# Altium schematic sheet H-CUBE.SchDoc
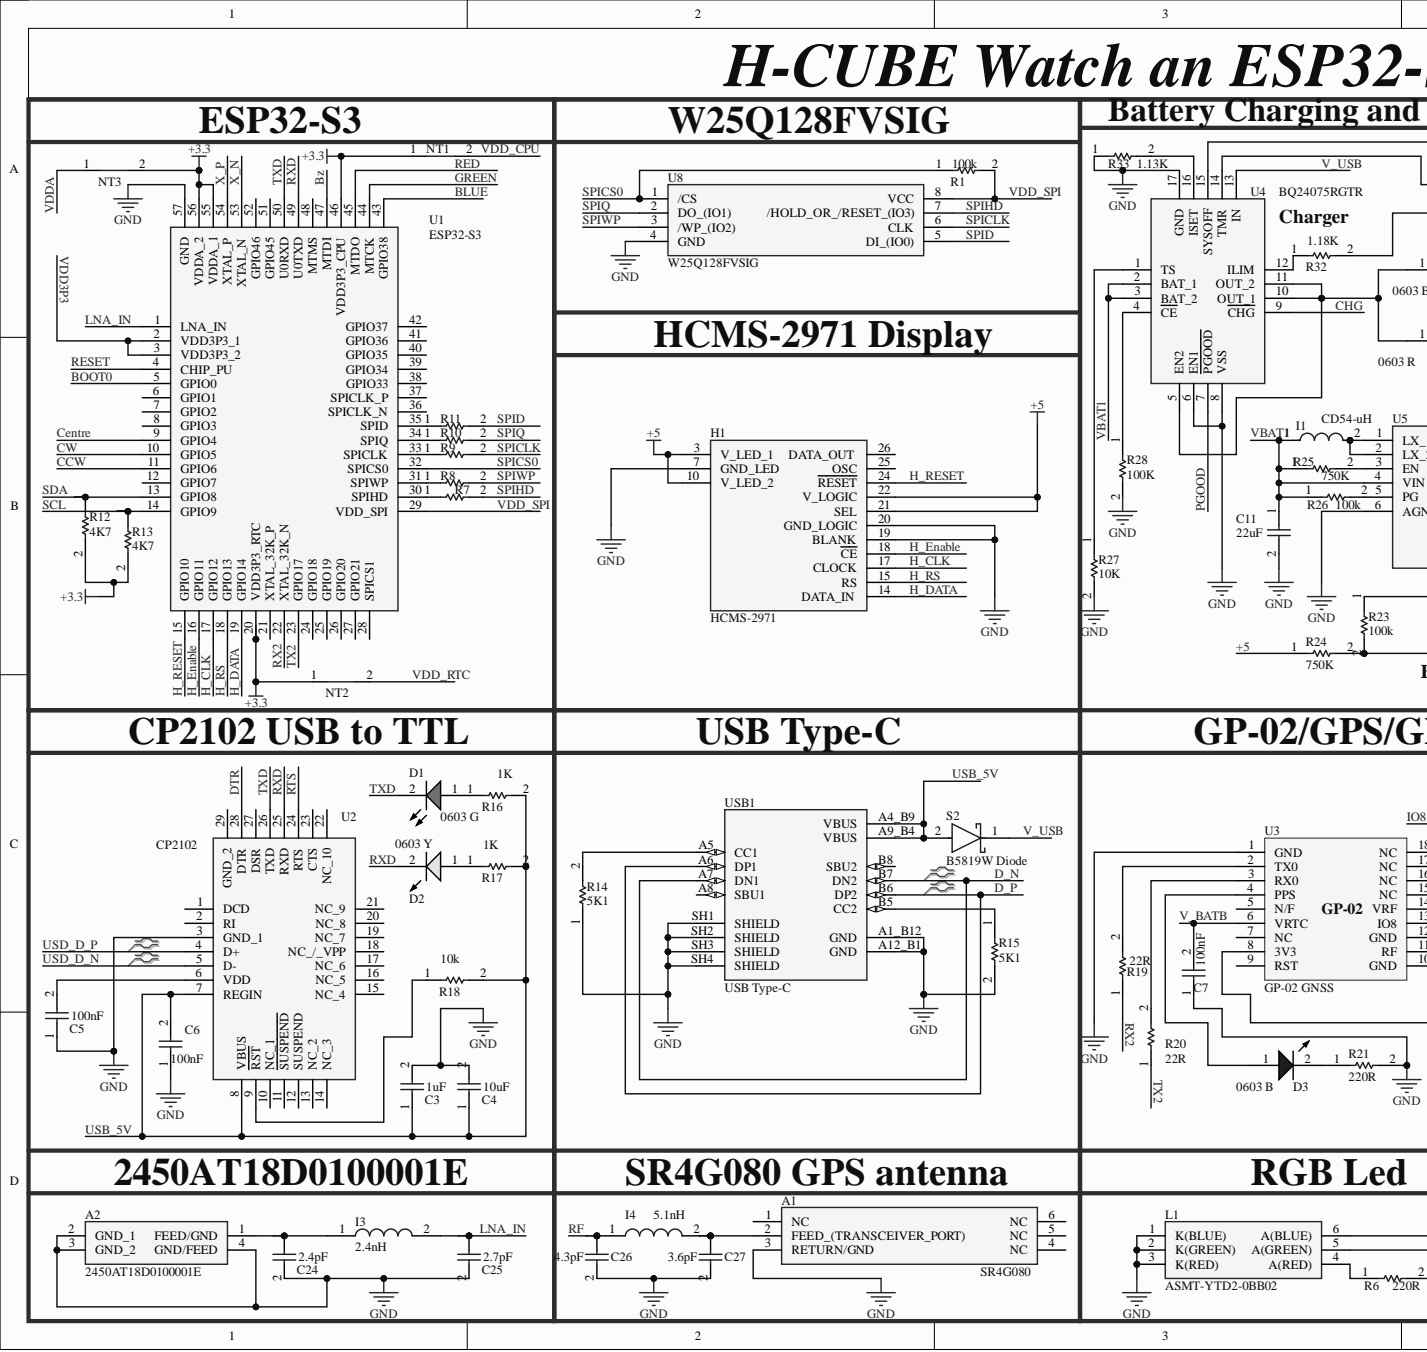
[diagram p0: H-CUBE.SchDoc, left]
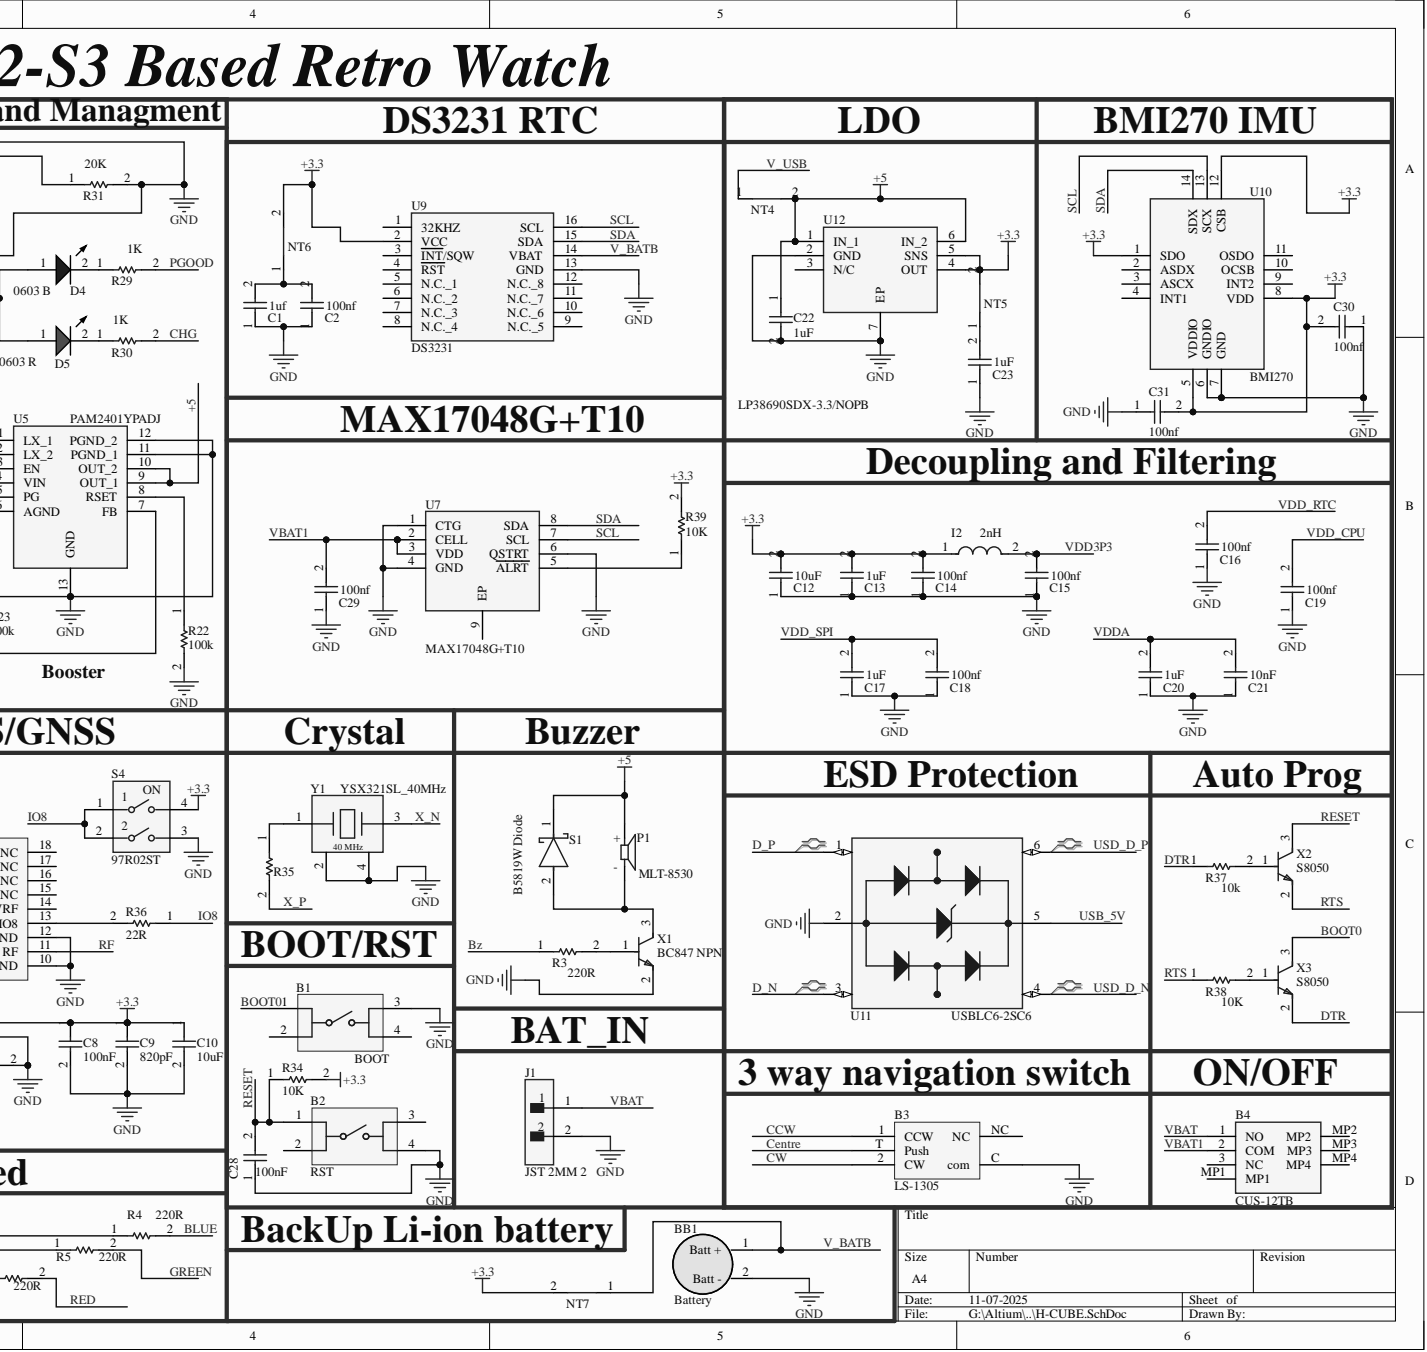
[diagram p1: H-CUBE.SchDoc, right]

## Parts
A1  SR4G080  [SR4G080]
A2  2450AT18D0100001E  [2450AT18D0100001E]
B1  BOOT  [YD-3414]
B2  RST  [YD-3414]
B3  LS-1305  [LS-1305]
B4  CUS-12TB  [CUS-12TB]
BB1  Battery  [Battery]
C1  1uf  [0603 CER CAP]
C2  100nf  [0603 CER CAP]
C3  1uF  [0603 CER CAP]
C4  10uF  [0603 CER CAP]
C5  100nF  [0603 CER CAP]
C6  100nF  [0603 CER CAP]
C7  100nF  [0603 CER CAP]
C8  100nF  [0603 CER CAP]
C9  820pF  [0603 CER CAP]
C10  10uF  [0603 CER CAP]
C11  22uF  [0603 CER CAP]
C12  10uF  [0603 CER CAP]
C13  1uF  [0603 CER CAP]
C14  100nf  [0603 CER CAP]
C15  100nf  [0603 CER CAP]
C16  100nf  [0603 CER CAP]
C17  1uF  [0603 CER CAP]
C18  100nf  [0603 CER CAP]
C19  100nf  [0603 CER CAP]
C20  1uF  [0603 CER CAP]
C21  10nF  [0603 CER CAP]
C22  1uF  [0603 CER CAP]
C23  1uF  [0603 CER CAP]
C24  2.4pF  [CER_0402_CAP]
C25  2.7pF  [CER_0402_CAP]
C26  4.3pF  [CER_0402_CAP]
C27  3.6pF  [CER_0402_CAP]
C28  100nF  [0603 CER CAP]
C29  100nf  [0603 CER CAP]
C30  100nf  [0603 CER CAP]
C31  100nf  [0603 CER CAP]
D1  0603 G  [0603 LED G]
D2  0603 Y  [0603 Y]
D3  0603 B  [0603 B]
D4  0603 B  [0603 B]
D5  0603 R  [0603 R]
H1  HCMS-2971  [HCMS-2971]
I1  CD54-uH  [CD54 Power inductor]
I2  2nH  [744761020A]
I3  2.4nH  [LQG15WZ2N4B02D]
I4  5.1nH  [LQG15HN5N1S02D]
J1  JST 2MM 2  [JST 2MM 2]
L1  ASMT-YTD2-0BB02  [ASMT-YTD2-0BB02]
NT1  NT  [NT']
NT2  NT  [NT']
NT3  NT  [NT']
NT4  NT  [NT']
NT5  NT  [NT']
NT6  NT  [NT']
NT7  NT  [NT']
P1  MLT-8530  [MLT-8530]
R1  100k  [0603 SMD RES]
R3  220R  [0603 SMD RES]
R4  220R  [0603 SMD RES]
R5  220R  [0603 SMD RES]
R6  220R  [0603 SMD RES]
R7  0R  [0603 SMD RES]  (value not drawn)
R8  0R  [0603 SMD RES]  (value not drawn)
R9  0R  [0603 SMD RES]  (value not drawn)
R10  0R  [0603 SMD RES]  (value not drawn)
R11  0R  [0603 SMD RES]  (value not drawn)
R12  4K7  [0603 SMD RES]
R13  4K7  [0603 SMD RES]
R14  5K1  [0603 SMD RES]
R15  5K1  [0603 SMD RES]
R16  1K  [0603 SMD RES]
R17  1K  [0603 SMD RES]
R18  10k  [0603 SMD RES]
R19  22R  [0603 SMD RES]
R20  22R  [0603 SMD RES]
R21  220R  [0603 SMD RES]
R22  100k  [0603 SMD RES]
R23  100k  [0603 SMD RES]
R24  750K  [0603 SMD RES]
R25  750K  [0603 SMD RES]
R26  100k  [0603 SMD RES]
R27  10K  [0603 SMD RES]
R28  100K  [0603 SMD RES]
R29  1K  [0603 SMD RES]
R30  1K  [0603 SMD RES]
R31  20K  [0603 SMD RES]
R32  1.18K  [0603 SMD RES]
R33  1.13K  [0603 SMD RES]
R34  10K  [0603 SMD RES]
R35  0R  [0603 SMD RES]  (value not drawn)
R36  22R  [0603 SMD RES]
R37  10k  [0603 SMD RES]
R38  10K  [0603 SMD RES]
R39  10K  [0603 SMD RES]
S1  B5819W Diode  [B5819W]
S2  B5819W Diode  [B5819W]
S4  97R02ST  [97R02ST]
U1  ESP32-S3  [ESP32-S3]
U2  CP2102  [CP2102]
U3  GP-02 GNSS  [GP-02]
U4  BQ24075RGTR  [BQ24075RGTR]
U5  PAM2401YPADJ  [PAM2401YPADJ]
U7  MAX17048G+T10  [MAX17048G+T10]
U8  W25Q128FVSIG  [W25Q128FVSIG]
U9  DS3231  [DS3231]
U10  BMI270  [BMI270]
U11  USBLC6-2SC6  [USBLC6-2SC6]
U12  LP38690SDX-3.3/NOPB  [LP38690SDX-3.3/NOPB]
USB1  USB Type-C  [USB Type-C 16P]
X1  BC847 NPN  [BC847]
X2  S8050  [S8050 J3Y]
X3  S8050  [S8050 J3Y]
Y1  YSX321SL_40MHz  [YSX321SL]

## Nets
+3.3: C8.1 C9.1 C10.1 C12.2 C13.2 C14.2 C30.2 C31.2 I2.1 NT1.1 NT2.1 NT3.2 NT5.2 NT6.2 NT7.2 R12.2 R13.2 R34.2 R39.2 S4.4 U1.20(VDD3P3_RTC) U1.46(VDD3P3_CPU) U1.55(VDDA_1) U1.56(VDDA_2) U3.8(3V3) U9.2(VCC) U10.1(SDO) U10.5(VDDIO) U10.8(VDD) U10.12(CSB) U12.4(OUT) U12.5(SNS)
GND: A1.3(RETURN/GND) A2.2(GND_1) A2.3(GND_2) A2.4(GND/FEED) B1.3 B2.4 B3.C(com) BB1.2 C1.1 C2.1 C3.2 C4.2 C5.1 C6.1 C7.1 C8.2 C9.2 C10.2 C11.2 C12.1 C13.1 C14.1 C15.1 C16.1 C17.1 C18.1 C19.1 C20.1 C21.1 C22.2 C23.1 C24.2 C25.2 C26.2 C27.2 C28.1 C29.1 C30.1 C31.1 H1.7(GND_LED) H1.19(BLANK) H1.20(GND_LOGIC) J1.2 L1.1(K(BLUE)) L1.2(K(GREEN)) L1.3(K(RED)) R14.1 R15.2 R21.2 R22.2 R23.1 R27.2 R28.2 R31.2 R32.2 R33.1 S4.3 U1.57(GND) U2.3(GND_1) U3.1(GND) U3.10(GND) U3.12(GND) U4.6(EN1) U4.8(VSS) U4.15(SYSOFF) U4.17(GND) U5.6(AGND) U5.11(PGND_1) U5.12(PGND_2) U5.13(GND) U7.1(CTG) U7.4(GND) U7.6(QSTRT) U8.4(GND) U9.13(GND) U10.6(GNDIO) U10.7(GND) U11.2 U12.2(GND) U12.7(EP) USB1.A1_B12(GND) USB1.A12_B1(GND) USB1.SH1(SHIELD) USB1.SH2(SHIELD) USB1.SH3(SHIELD) USB1.SH4(SHIELD) X1.2 Y1.2 Y1.4
+5: C22.1 H1.3(V_LED_1) H1.10(V_LED_2) H1.21(SEL) H1.22(V_LOGIC) NT4.2 P1.P R24.1 S1.1 U5.9(OUT_1) U5.10(OUT_2) U12.1(IN_1) U12.6(IN_2)
BLUE: R4.2 U1.43(GPIO38)
BOOT0: B1.1 U1.5(GPIO0) X3.3
Bz: R3.1 U1.47(MTDI)
CCW: B3.1(CCW) U1.11(GPIO6)
Centre: B3.T(Push) U1.9(GPIO4)
CHG: R30.2 U4.9(CHG)
CW: B3.2(CW) U1.10(GPIO5)
D_N: U11.3 USB1.A7(DN1) USB1.B7(DN2)
D_P: U11.1 USB1.A6(DP1) USB1.B6(DP2)
DTR: R37.1 U2.28(DTR) X3.2
GREEN: R5.2 U1.44(MTCK)
H_CLK: H1.17(CLOCK) U1.17(GPIO12)
H_DATA: H1.14(DATA_IN) U1.19(GPIO14)
H_Enable: H1.18(CE) U1.16(GPIO11)
H_RESET: H1.24(RESET) U1.15(GPIO10)
H_RS: H1.15(RS) U1.18(GPIO13)
IO8: R36.1 S4.1 S4.2
LNA_IN: C25.1 I3.2 U1.1(LNA_IN)
PGOOD: R29.2 U4.7(PGOOD)
RED: R6.2 U1.45(MTDO)
RESET: B2.1 C28.2 R34.1 U1.4(CHIP_PU) X2.3
RF: C26.1 I4.1 U3.11(RF)
RTS: R38.1 U2.24(RTS) X2.2
RX2: R19.1 U1.22(XTAL_32K_N)
RXD: D2.2 U1.49(U0TXD) U2.25(RXD)
SCL: R13.1 U1.14(GPIO9) U7.7(SCL) U9.16(SCL) U10.13(SCX)
SDA: R12.1 U1.13(GPIO8) U7.8(SDA) U9.15(SDA) U10.14(SDX)
SPICLK: R9.2 U8.6(CLK)
SPICS0: R1.1 U1.32(SPICS0) U8.1(/CS)
SPID: R11.2 U8.5(DI_(IO0))
SPIHD: R7.2 U8.7(/HOLD_OR_/RESET_(IO3))
SPIQ: R10.2 U8.2(DO_(IO1))
SPIWP: R8.2 U8.3(/WP_(IO2))
TX2: R20.1 U1.23(GPIO17)
TXD: D1.2 U1.50(U0RXD) U2.26(TXD)
USB_5V: C3.1 C4.1 C6.2 R16.2 R17.2 R18.2 S2.2 U2.7(REGIN) U2.8(VBUS) U11.5 USB1.A4_B9(VBUS) USB1.A9_B4(VBUS)
USD_D_N: U2.5(D-) U11.4
USD_D_P: U2.4(D+) U11.6
V_BATB: BB1.1 C7.2 NT7.1 U3.6(VRTC) U9.14(VBAT)
V_USB: NT4.1 S2.1 U4.13(IN)
VBAT: B4.1(NO) J1.1
VBAT1: B4.2(COM) C11.1 C29.2 I1.1 R25.1 R26.1 U4.2(BAT_1) U4.3(BAT_2) U5.4(VIN) U7.2(CELL) U7.3(VDD)
VDD3P3: C15.2 I2.2 U1.2(VDD3P3_1) U1.3(VDD3P3_2)
VDD_CPU: C19.2 NT1.2
VDD_RTC: C16.2 NT2.2
VDD_SPI: C17.2 C18.2 R1.2 U1.29(VDD_SPI) U8.8(VCC)
VDDA: C20.2 C21.2 NT3.1
X_N: U1.53(XTAL_N) Y1.3
X_P: R35.2 U1.54(XTAL_P)
NetA1_2: A1.2(FEED_(TRANSCEIVER_PORT)) C27.1 I4.2
NetA2_1: A2.1(FEED/GND) C24.1 I3.1
NetC1_2: C1.2 C2.2 NT6.1
NetC5_2: C5.2 U2.6(VDD)
NetC23_2: C23.2 NT5.1
NetD1_1: D1.1 R16.1
NetD2_1: D2.1 R17.1
NetD3_1: D3.1 U3.4(PPS)
NetD3_2: D3.2 R21.1
NetD4_1: D4.1 D5.1 U4.5(EN2) U4.10(OUT_1) U4.11(OUT_2)
NetD4_2: D4.2 R29.1
NetD5_2: D5.2 R30.1
NetI1_2: I1.2 U5.1(LX_1) U5.2(LX_2)
NetL1_4: L1.4(A(RED)) R6.1
NetL1_5: L1.5(A(GREEN)) R5.1
NetL1_6: L1.6(A(BLUE)) R4.1
NetP1_N: P1.N S1.2 X1.3
NetR3_2: R3.2 X1.1
NetR7_1: R7.1 U1.30(SPIHD)
NetR8_1: R8.1 U1.31(SPIWP)
NetR9_1: R9.1 U1.33(SPICLK)
NetR10_1: R10.1 U1.34(SPIQ)
NetR11_1: R11.1 U1.35(SPID)
NetR14_2: R14.2 USB1.A5(CC1)
NetR15_1: R15.1 USB1.B5(CC2)
NetR18_1: R18.1 U2.9(RST)
NetR19_2: R19.2 U3.2(TX0)
NetR20_2: R20.2 U3.3(RX0)
NetR22_1: R22.1 U5.8(RSET)
NetR23_2: R23.2 R24.2 U5.7(FB)
NetR25_2: R25.2 U5.3(EN)
NetR26_2: R26.2 U5.5(PG)
NetR27_1: R27.1 U4.1(TS)
NetR28_1: R28.1 U4.4(CE)
NetR31_1: R31.1 U4.14(TMR)
NetR32_1: R32.1 U4.12(ILIM)
NetR33_2: R33.2 U4.16(ISET)
NetR35_1: R35.1 Y1.1
NetR36_2: R36.2 U3.13(IO8)
NetR37_2: R37.2 X2.1
NetR38_2: R38.2 X3.1
NetR39_1: R39.1 U7.5(ALRT)

Not connected: A1 1(NC) 4(NC) 5(NC) 6(NC); B1 2 4; B2 2 3; B3 NC; B4 3(NC) MP1 MP2 MP3 MP4; H1 25(OSC) 26(DATA_OUT); U1 6(GPIO1) 7(GPIO2) 8(GPIO3) 12(GPIO7) 21(XTAL_32K_P) 24(GPIO18) 25(GPIO19) 26(GPIO20) 27(GPIO21) 28(SPICS1) 36(SPICLK_N) 37(SPICLK_P) 38(GPIO33) 39(GPIO34) 40(GPIO35) 41(GPIO36) 42(GPIO37) 48(MTMS) 51(GPIO45) 52(GPIO46); U2 1(DCD) 2(RI) 10(NC_1) 11(SUSPEND) 12(SUSPEND) 13(NC_2) 14(NC_3) 15(NC_4) 16(NC_5) 17(NC_6) 18(NC_/_VPP) 19(NC_7) 20(NC_8) 21(NC_9) 22(NC_10) 23(CTS) 27(DSR) 29(GND_2); U3 5(N/F) 7(NC) 9(RST) 14(VRF) 15(NC) 16(NC) 17(NC) 18(NC); U7 9(EP); U9 1(32KHZ) 3(INT/SQW) 4(RST) 5(N.C._1) 6(N.C._2) 7(N.C._3) 8(N.C._4) 9(N.C._5) 10(N.C._6) 11(N.C._7) 12(N.C._8); U10 2(ASDX) 3(ASCX) 4(INT1) 9(INT2) 10(OCSB) 11(OSDO); U12 3(N/C); USB1 A8(SBU1) B8(SBU2)

## Library data of the parts
A1 = SR4G080: GNSS Antenna chip type; Component Kind: Standard; Library Name: Antenna.SchLib
A2 = 2450AT18D0100001E: 2.4GHz Chip RF Antenna 2.4GHz ~ 2.5GHz 1.5dBi Solder Surface Mount; Component Kind: Standard; Library Name: Antenna.SchLib
B1 B2 = YD-3414: Component Kind: Standard; Library Name: Switches.SchLib
B3 = LS-1305: 3 way navigation switch  Spring return, center push, Single knob can move CW/CCW/PUSH                                                          Specification:-  Rating    10mA.5V DC  Contact resitance    100MΩ max.  Operating temperature range    -30°C to +85°C  Lifetime    100,000 Cycles min  Operating force    Lever portion 120±30gf   /  Push portion205±50gf  Travel    3-direction-25°-0°~+25° / push portion 0.90±0.1mm; Component Kind: Standard; Library Name: Switches.SchLib
C1-C23 C28-C31 = 0603_SMD: 0603 ceramic capacitor; Component Kind: Standard; Library Name: Non_Polar Capacitor.SchLib
C24-C27 = 0402_SMD: 0402; Component Kind: Standard; Library Name: Non_Polar Capacitor.SchLib
H1 = HCMS-2971: LED Displays & Accessories Yelow 585nm 1x8 Alphanumeric; Component Kind: Standard; Library Name: Displays.SchLib
I1 = CD54 Inductor: Fixed Inductors SMD Power Inductor Unshielded; Component Kind: Standard; Library Name: SMD Components.SchLib
I2 = 744761020A: RF Inductors - SMD WE-KI 0603 2nH 700mA 80mOhms 0.2nH AEC-Q200; Component Kind: Standard; Library Name: SMD Components.SchLib
I3 = LQG15WZ2N4B02D: Component Kind: Standard; Library Name: SMD Components.SchLib
I4 = LQG15HN5N1S02D: Component Kind: Standard; Library Name: SMD Components.SchLib
P1 = MLT-8530: PCB Mount type, SMD, External Drive, Magnetic 2700Hz 80dB Size Square 8.5x8.5x3mm, Low Profile, Sound output on side.; Component Kind: Standard; Library Name: Misc Components.SchLib
R1 R3-R39 = RES_0603_SMD: Component Kind: Standard; Library Name: Resistors.SchLib
S1 S2 = B5819W: 1N5819 B5819W 1N5819W SOD123 (1206) 1A 40V Schottky Diode; Component Kind: Standard; Library Name: Diodes.SchLib
U2 = CP2102: USB-to-UART 1-CH 640byte FIFO 3.3V Automotive 28-Pin QFN EP Tube; Component Kind: Standard; Library Name: General ICs.SchLib
U3 = GP-02: GP-02 is a high-performance BDS/GNSS multi-mode satellite navigation receiver SOCmodule, which integrates RF front-end, digital baseband processor, 32-bit RISCCPU, power management and active antenna detection and protection functions. Support avariety of satellite navigation systems, including China's Beidou satellite navigationsystem BDS, the United States' GPS, and Russia's GLONASS, which canrealizemulti-system joint positioning; Component Kind: Standard; Library Name: General ICs.SchLib
U4 = BQ24075RGTR: Battery Management Li-Ion Batt Chrgr & Pwr-Path Mgmt IC A 595-BQ24075RGTT; Component Kind: Standard; Library Name: General ICs.SchLib
U5 = PAM2401YPADJ: Switching Voltage Regulators 1.0MHz Step-Up Conv 0.9 to 4.75V 3A 1KHz; Component Kind: Standard; Library Name: General ICs.SchLib
U7 = MAX17048G+T10: Battery Management 3 A 1-Cell/2-Cell Fuel Gauge with ModelGauge; Component Kind: Standard; Library Name: General ICs.SchLib
U10 = BMI270: The BMI270 is an ultra-low power smart IMU optimized for wearable and hearable applications; Component Kind: Standard; Library Name: General ICs.SchLib
U12 = LP38690SDX-3.3/NOPB: LDO Voltage Regulators 1-A 10-V low-dropo ut voltage regulator A 926-LP38690SD33NOPB; Component Kind: Standard; Library Name: General ICs.SchLib
USB1 = USB Type-C 16P: Component Kind: Standard; Library Name: USBs.SchLib
X1 = BC847: Transistors Bipolar NPN 45V 100MA 100MHZ SOT23, SMD Eqv of BC547; Component Kind: Standard; Library Name: SMD Components.SchLib
X2 X3 = S8050: igh Current General Purpose NPN transistor Eqv MBT8050LT1G, BC817; Component Kind: Standard; Library Name: SMD Components.SchLib
Y1 = YSX321SL: Component Kind: Standard; Library Name: Crystals.SchLib
B4 = CUS-12TB: Component Kind: Standard; Library Name: Switches.SchLib
BB1 = Battery: Component Kind: Standard; Library Name: Misc Components.SchLib
D1 = 0603 G: Component Kind: Standard; Library Name: LEDs.SchLib
D2 = 0603 Y: Component Kind: Standard; Library Name: LEDs.SchLib
D3 D4 = 0603 B: Component Kind: Standard; Library Name: LEDs.SchLib
D5 = 0603 R: Component Kind: Standard; Library Name: LEDs.SchLib
J1 = JST 2MM 2: Component Kind: Standard; Library Name: n-Pin Connectors.SchLib
L1 = ASMT-YTD2-0BB02: Component Kind: Standard; Library Name: LEDs.SchLib
NT1-NT7 = NT: Component Kind: Standard; Library Name: General Perpose Compoments.SchLib
S4 = 97R02ST: Component Kind: Standard; Library Name: Switches.SchLib
U1 = ESP32-S3: Component Kind: Standard; Library Name: General ICs.SchLib
U8 = W25Q128FVSIG: Component Kind: Standard; Library Name: General ICs.SchLib
U9 = DS3231: Component Kind: Standard; Library Name: General ICs.SchLib
U11 = USBLC6-2SC6: Component Kind: Standard; Library Name: SMD Components.SchLib
